# Altium schematic sheet wifi_BT.SchDoc(wifi_BT)
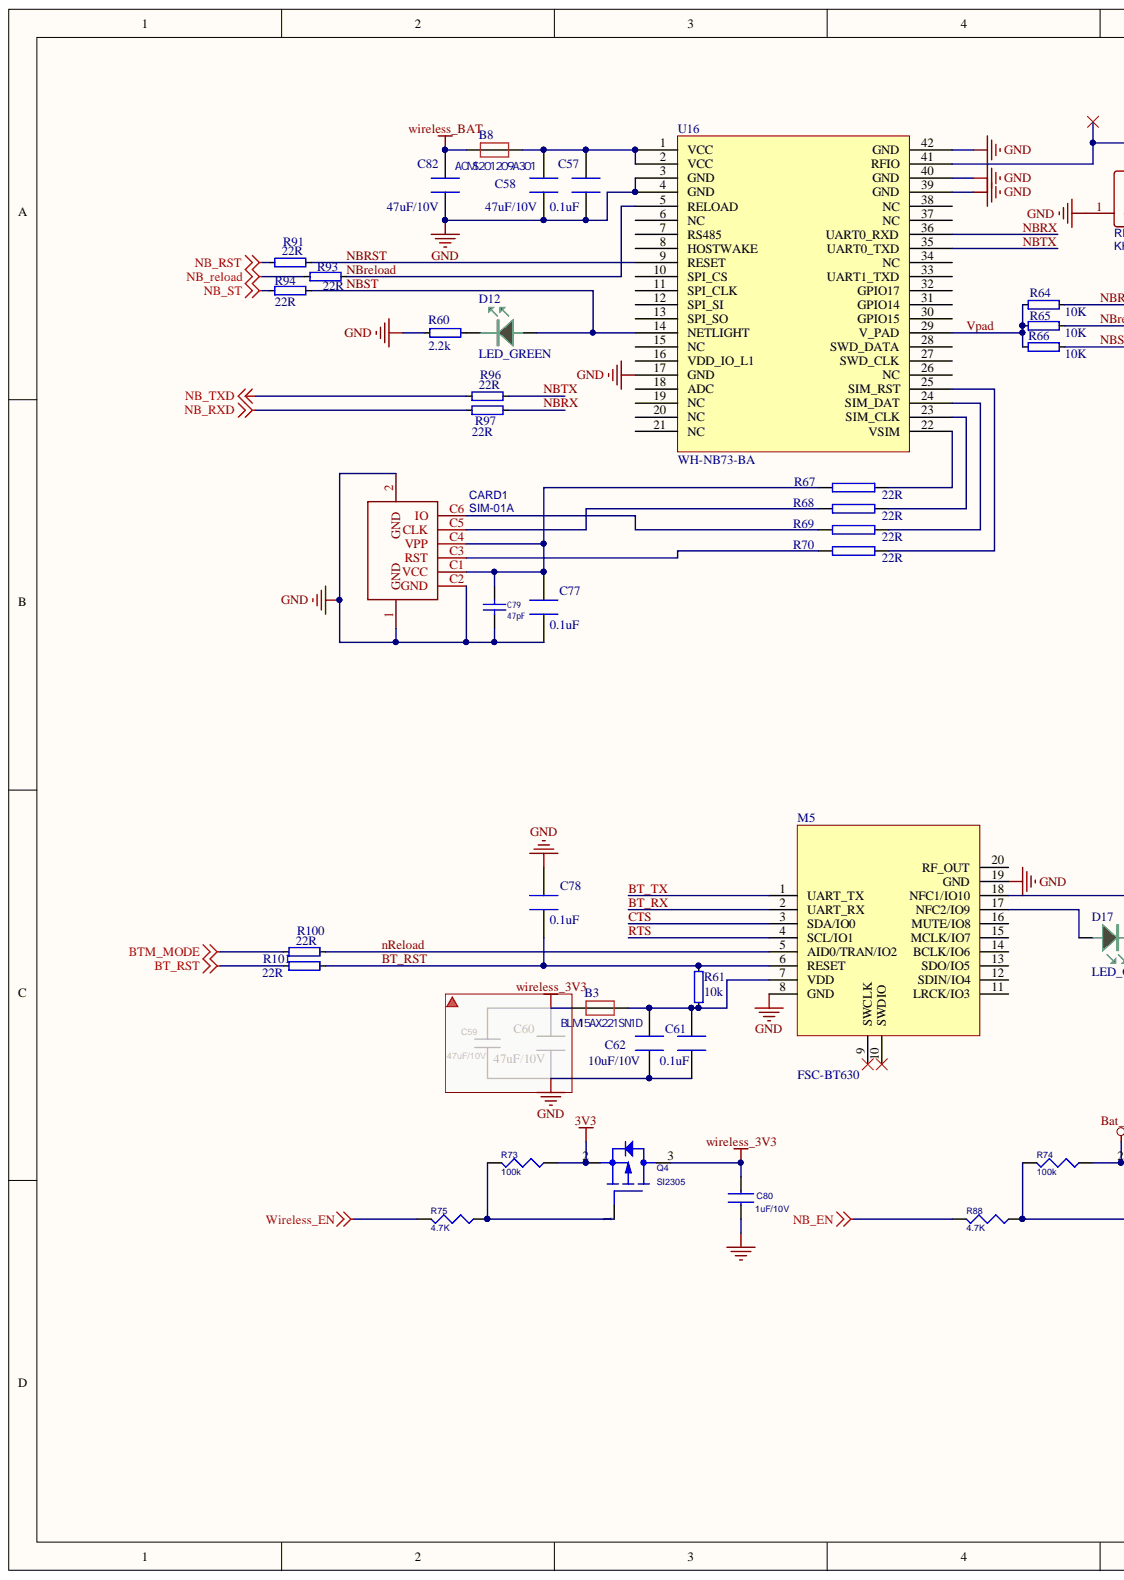
[diagram p0: wifi_BT.SchDoc, left]
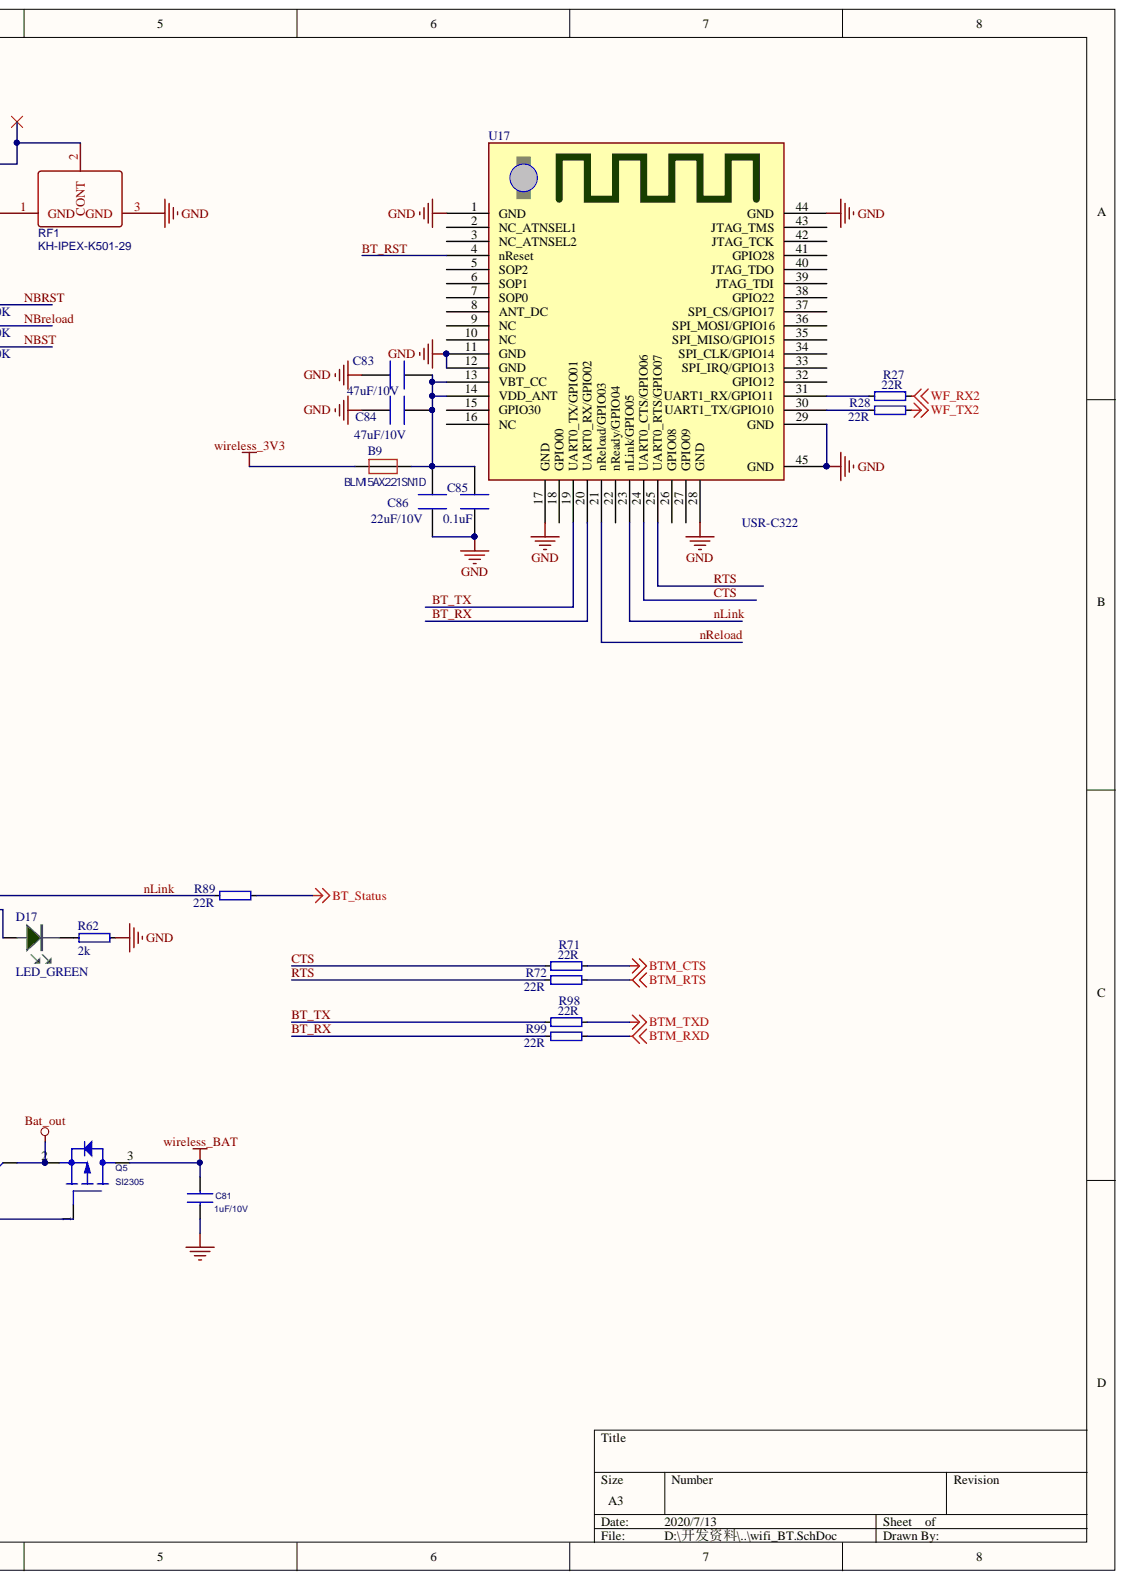
[diagram p1: wifi_BT.SchDoc, right]

## Parts
B3  BLM15AX221SN1D  [B0402]
B8  ACMS201209A301  [0805_R]
B9  BLM15AX221SN1D  [B0402]
C57  0.1uF  [0402_C]
C58  47uF/10V  [0805_C]
C61  0.1uF  [0402_C]
C62  10uF/10V  [0603_C]
C77  0.1uF  [0402_C]
C78  0.1uF  [0402_C]
C79  value 47pF  [CAPC0603(1608)100_L]  (hidden comment: CAP 47pF 16V 0603(1608))
C80  1uF/10V  [0402_C]
C81  1uF/10V  [0402_C]
C82  47uF/10V  [0805_C]
C83  47uF/10V  [0603_C]
C84  47uF/10V  [0603_C]
C85  0.1uF  [0402_C]
C86  22uF/10V  [0603_C]
CARD1  SIM-01A  [16.4MM*14.75MM]
D12  LED_GREEN  [0603_LED_S1]
D17  LED_GREEN  [0603_LED_S1]
M5  FSC-BT630  [FSC-BT630]
Q4  SI2305  [SOT23]
Q5  SI2305  [SOT23]
R27  22R  [0402]
R28  22R  [0402]
R60  2.2k  [0402]
R61  10k  [0402]
R62  2k  [0402]
R64  10K  [0402]
R65  10K  [0402]
R66  10K  [0402]
R67  22R  [0402_R]
R68  22R  [0402_R]
R69  22R  [0402_R]
R70  22R  [0402_R]
R71  22R  [0402]
R72  22R  [0402]
R73  100k  [0402_R]
R74  100k  [0402_R]
R75  4.7K  [0402_R]
R88  4.7K  [0402_R]
R89  22R  [0402]
R91  22R  [0402]
R93  22R  [0402]
R94  22R  [0402]
R96  22R  [0402]
R97  22R  [0402]
R98  22R  [0402]
R99  22R  [0402]
R100  22R  [0402]
R101  22R  [0402]
RF1  KH-IPEX-K501-29  [ANT-SMD_KH-IPEX-K501-29]
U16  WH-NB73-BA
U17  USR-C322  [USR-C322-PCB]

## Nets
3V3: Q4.2 R73.2
BAT_OUT: Q5.2 R74.2
BT_STATUS: R89.1
BTM_CTS: R71.1
BTM_MODE: R100.2
BTM_RTS: R72.1
BTM_RXD: R99.1
BTM_TXD: R98.1
GND: C57.2 C58.2 C61.2 C62.2 C77.1 C78.2 C79.1 C80.2 C81.2 C82.2 C83.1 C84.1 C85.2 C86.2 CARD1.1(GND) CARD1.2(GND) CARD1.C2(GND) M5.8(GND) M5.19(GND) R60.1 R62.1 RF1.1 RF1.3 U16.3(GND) U16.4(GND) U16.17(GND) U16.39(GND) U16.40(GND) U16.42(GND) U17.1(GND) U17.11(GND) U17.12(GND) U17.17(GND) U17.28(GND) U17.29(GND) U17.44(GND) U17.45(GND)
NB_EN: R88.1
NB_RELOAD: R93.2
NB_RST: R91.2
NB_RXD: R97.2
NB_ST: R94.2
NB_TXD: R96.2
WF_RX2: R27.1
WF_TX2: R28.1
WIRELESS_3V3: B3.1 B9.1 C80.1 Q4.3
WIRELESS_BAT: B8.1 C81.1 C82.1 Q5.3
WIRELESS_EN: R75.1
BT_RST: C78.1 M5.6(RESET) R61.1 R101.1 R101.2 U17.4(nReset)
BT_RX: M5.2(UART_RX) R99.2 U17.20(UART0_RX/GPIO02)
BT_TX: M5.1(UART_TX) R98.2 U17.19(UART0_TX/GPIO01)
CTS: M5.3(SDA/IO0) R71.2 U17.24(UART0_CTS/GPIO06)
NBRELOAD: R65.1 R93.1 U16.5(RELOAD)
NBRST: R64.1 R91.1 U16.9(RESET)
NBRX: R97.1 U16.36(UART0_RXD)
NBST: D12.A R66.1 R94.1 U16.14(NETLIGHT)
NBTX: R96.1 U16.35(UART0_TXD)
NLINK: M5.18(NFC1/IO10) R89.2 U17.23(nLink/GPIO05)
NRELOAD: M5.5(AID0/TRAN/IO2) R100.1 U17.21(nReload/GPIO03)
RTS: M5.4(SCL/IO1) R72.2 U17.25(UART0_RTS/GPIO07)
VPAD: R64.2 R65.2 R66.2 U16.29(V_PAD)
NetB3_2: B3.2 C61.1 C62.1 M5.7(VDD) R61.2
NetB8_2: B8.2 C57.1 C58.1 U16.1(VCC) U16.2(VCC)
NetB9_2: B9.2 C83.2 C84.2 C85.1 C86.1 U17.13(VBT_CC) U17.14(VDD_ANT)
NetC77_2: C77.2 C79.2 CARD1.C1(VCC) CARD1.C4(VPP) R67.1
NetCARD1_C3: CARD1.C3(RST) R70.1
NetCARD1_C5: CARD1.C5(CLK) R68.1
NetCARD1_C6: CARD1.C6(IO) R69.1
NetD12_K: D12.K R60.2
NetD17_A: D17.A M5.17(NFC2/IO9)
NetD17_K: D17.K R62.2
NetQ4_1: Q4.1 R73.1 R75.2
NetQ5_1: Q5.1 R74.1 R88.2
NetR27_2: R27.2 U17.31(UART1_RX/GPIO11)
NetR28_2: R28.2 U17.30(UART1_TX/GPIO10)
NetR67_2: R67.2 U16.22(VSIM)
NetR68_2: R68.2 U16.23(SIM_CLK)
NetR69_2: R69.2 U16.24(SIM_DAT)
NetR70_2: R70.2 U16.25(SIM_RST)
NetRF1_2: RF1.2 U16.41(RFIO)

Not connected: M5 9(SWCLK) 10(SWDIO) 11(LRCK/IO3) 12(SDIN/IO4) 13(SDO/IO5) 14(BCLK/IO6) 15(MCLK/IO7) 16(MUTE/IO8) 20(RF_OUT); U16 6(NC) 7(RS485) 8(HOSTWAKE) 10(SPI_CS) 11(SPI_CLK) 12(SPI_SI) 13(SPI_SO) 15(NC) 16(VDD_IO_L1) 18(ADC) 19(NC) 20(NC) 21(NC) 26(NC) 27(SWD_CLK) 28(SWD_DATA) 30(GPIO15) 31(GPIO14) 32(GPIO17) 33(UART1_TXD) 34(NC) 37(NC) 38(NC); U17 2(NC_ATNSEL1) 3(NC_ATNSEL2) 5(SOP2) 6(SOP1) 7(SOP0) 8(ANT_DC) 9(NC) 10(NC) 15(GPIO30) 16(NC) 18(GPIO00) 22(nReady/GPIO04) 26(GPIO08) 27(GPIO09) 32(GPIO12) 33(SPI_IRQ/GPIO13) 34(SPI_CLK/GPIO14) 35(SPI_MISO/GPIO15) 36(SPI_MOSI/GPIO16) 37(SPI_CS/GPIO17) 38(GPIO22) 39(JTAG_TDI) 40(JTAG_TDO) 41(GPIO28) 42(JTAG_TCK) 43(JTAG_TMS)

## Library data of the parts
B3 B8 B9 = Bead: Component Kind: Standard; Library Name: RP3288BV03_20180126-.SCHLIB
C57 C61 C77 C78 C85 = STD-06-0048: CHIP CAPACITOR, CERAMIC, 100NF, 16V, 10%, X7R, 0402; Manufacturer: Yageo; Manufacturer Part Number: CC0402KRX7R7BB104; Approx Volume Cost ($): 0.003; Database Table Name: MLCC$; Component Kind: Standard; Dielectric: X7R; Part Number: STD-06-0048; Rated Voltage: 16V; Tolerance: 10%; VALUE: 0.1uF; Library Name: JG_StandardLibrary.DbLib
C58 C62 C82-C84 C86 = STD-06-0048: CHIP CAPACITOR, CERAMIC, 100NF, 16V, 10%, X7R, 0402; Manufacturer: Yageo; Manufacturer Part Number: CC0402KRX7R7BB104; Approx Volume Cost ($): 0.003; Database Table Name: MLCC$; VALUE: 100N; Component Kind: Standard; Dielectric: X7R; Part Number: STD-06-0048; Rated Voltage: 16V; Tolerance: 10%; Library Name: JG_StandardLibrary.DbLib
C79 = CMP-1035-01048-1: CAP 47pF 16V ±5pF 0603 (1608 Metric) Thickness 1mm SMD; Case-EIA: 0603; Case-Metric: 1608; Component Kind: Standard; Library Name: Altium Content Vault; Max Thickness: 1 mm; Rated Voltage: 16 V; Technology: SMT; Tolerance: ±5pF
C80 C81 = CAP NP_Dup2: CAP,MLCC,100nF,+/-10%,X7R,6.3V,SMD0402; Component Kind: Standard
CARD1 = SIM-01A: BOM_Manufacturer: HRO; BOM_Manufacturer Part: SIM-01A; BOM_Supplier: LCSC; BOM_Supplier Part: C99403; Component Kind: Standard; spicePre: C
D12 D17 = LED_0603_GREEN: MANUFACTURER: KINGBRIGHT; PART: KP-3216CGCK; Component Kind: Standard; Description: LED_0603_GREEN; FARNELL: 2290333; Library Name: Arbury_SCH.SchLib
Q4 Q5 = FET P MOS: Component Kind: Standard
R27 R28 R60-R62 R64-R66 R71 R72 R89 R91 R93 R94 R96-R101 = R0402-12k: Resistor, ?%, 0.063W; Artikkelnr Noca: 27049099; Database Table Name: Resistors$; Note: Chip Res 1%; Value: 12k; Component Kind: Standard; LatestRevisionDate: 2009-11-23; Library Name: Nordic Passive DB.DbLib; Part Number: R0402-12k; Pin Count: 2; Published: 2009-05-08; Supplier: Farnell; Supplier part number: 173-8865; Publisher: Nordic Semiconductor
R67-R70 = RES2: Component Kind: Standard
R73-R75 R88 = RESISTOR_1: RES,thick film,47KOhm,+/-5%,1/16W,SMD0402; Component Kind: Standard
RF1 = KH-IPEX-K501-29: BOM_Manufacturer: Shenzhen Kinghelm Elec; Contributor: LCSC; BOM_Manufacturer Part: KH-IPEX-K501-29; BOM_Supplier: LCSC; BOM_Supplier Part: C411563; Component Kind: Standard; spicePre: R; spiceSymbolName: KH-IPEX-K501-29
U16 = WH-NB73-BA: EXTERNAL ANTENNA; Component Kind: Standard; Library Name: WH-NB73 V1.1.SchLib
M5 = FSC-BT630: Component Kind: Standard; Library Name: 振动采集器.SCHLIB
U17 = USR-C322: Component Kind: Standard; Library Name: USR-C322.SchLib
